# Altium schematic sheet MCU.SchDoc
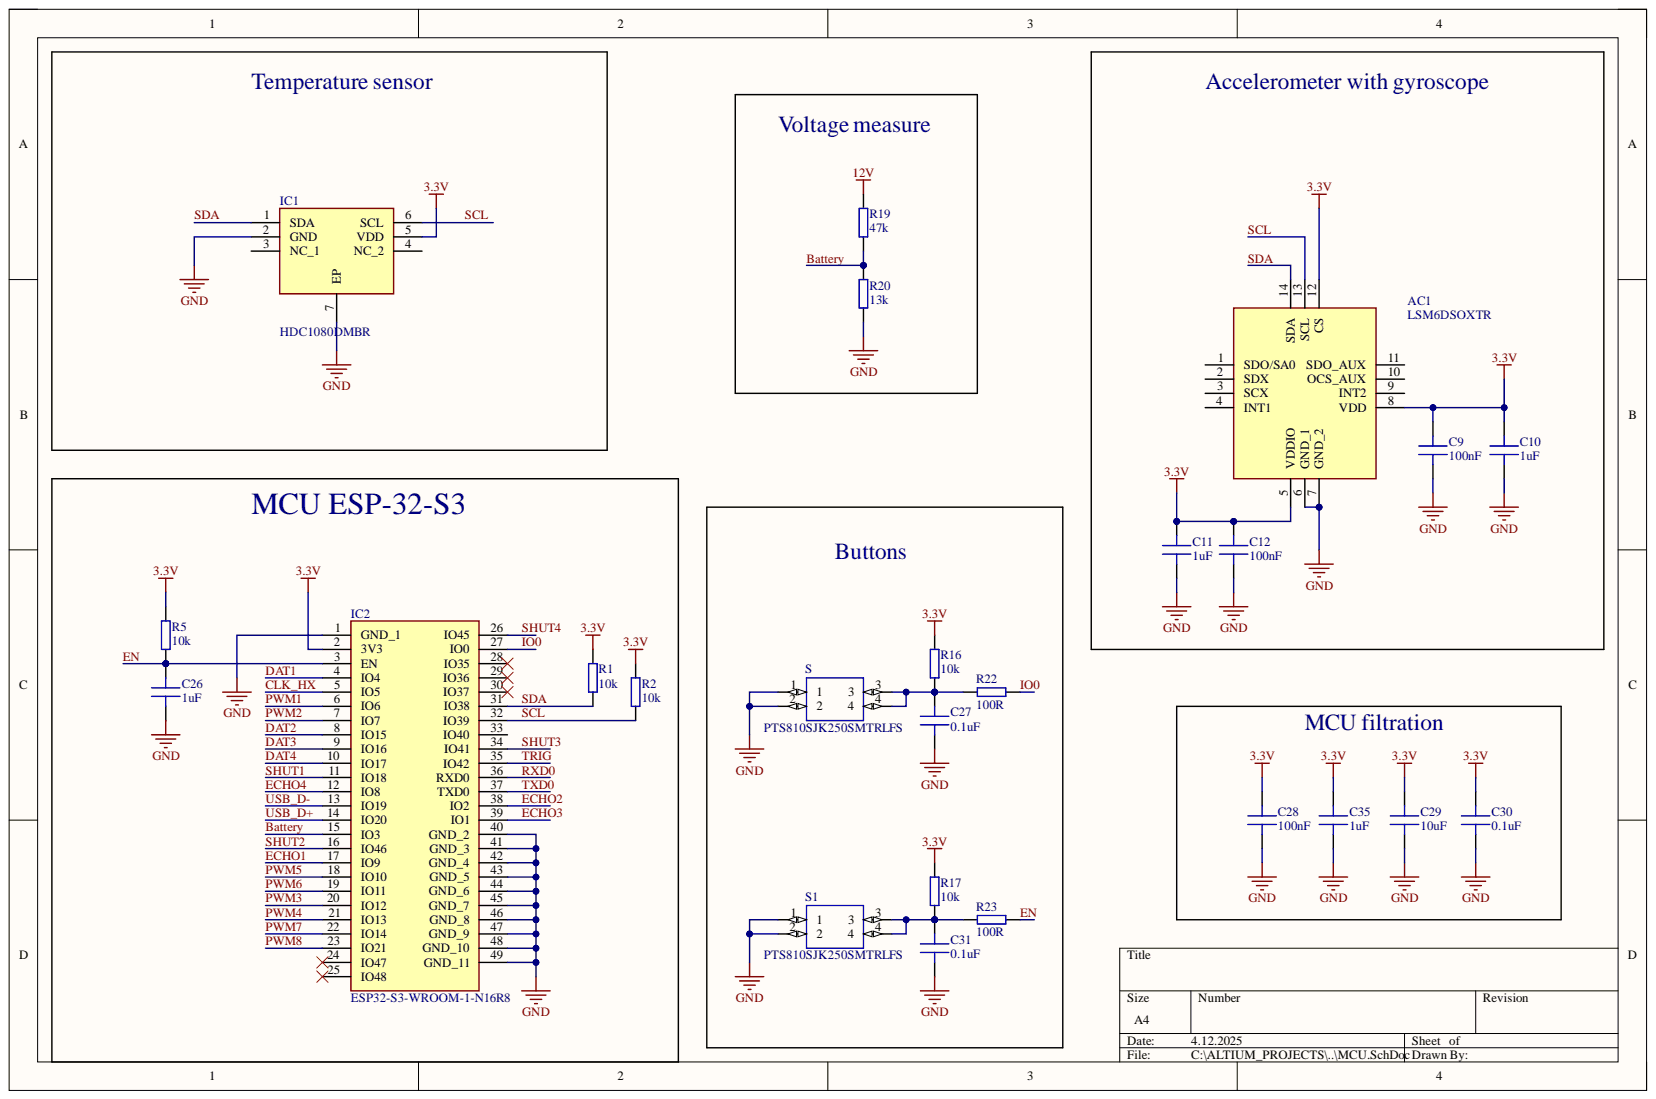
[diagram p0: MCU.SchDoc]

## Parts
AC1  LSM6DSOXTR  [LGA-14_1]
C9  100nF  [C 0402]
C10  1uF  [C 0402]
C11  1uF  [C 0402]
C12  100nF  [C 0402]
C26  1uF  [C 0402]
C27  0.1uF  [C 0402]
C28  100nF  [C 0402]
C29  10uF  [C 0402]
C30  0.1uF  [C 0402]
C31  0.1uF  [C 0402]
C35  1uF  [C 0402]
IC1  HDC1080DMBR  [SON100P300X300X80-7N-D]
IC2  ESP32-S3-WROOM-1-N16R8  [ESP32S3WROOM1N16R8]
R1  10k  [R 0402]
R2  10k  [R 0402]
R5  10k  [R 0402]
R16  10k  [R 0402]
R17  10k  [R 0402]
R19  47k  [R 0402]
R20  13k  [R 0402]
R22  100R  [R 0402]
R23  100R  [R 0402]
S  PTS810SJK250SMTRLFS  [PTS810SJG250SMTRLFS]
S1  PTS810SJK250SMTRLFS  [PTS810SJG250SMTRLFS]

## Nets
3.3V: AC1.5(VDDIO) AC1.8(VDD) AC1.12(CS) C9.1 C10.1 C11.1 C12.1 C28.1 C29.1 C30.1 C35.1 IC1.5(VDD) IC2.2(3V3) R1.2 R2.2 R5.2 R16.2 R17.2
12V: R19.2
GND: AC1.6(GND_1) AC1.7(GND_2) C9.2 C10.2 C11.2 C12.2 C26.2 C27.2 C28.2 C29.2 C30.2 C31.2 C35.2 IC1.2(GND) IC1.7(EP) IC2.1(GND_1) IC2.40(GND_2) IC2.41(GND_3) IC2.42(GND_4) IC2.43(GND_5) IC2.44(GND_6) IC2.45(GND_7) IC2.46(GND_8) IC2.47(GND_9) IC2.48(GND_10) IC2.49(GND_11) R20.1 S.1 S.2 S1.1 S1.2
Battery: IC2.15(IO3) R19.1 R20.2
CLK_HX: IC2.5(IO5)
DAT1: IC2.4(IO4)
DAT2: IC2.8(IO15)
DAT3: IC2.9(IO16)
DAT4: IC2.10(IO17)
ECHO1: IC2.17(IO9)
ECHO2: IC2.38(IO2)
ECHO3: IC2.39(IO1)
ECHO4: IC2.12(IO8)
EN: C26.1 IC2.3(EN) R5.1 R23.1
IO0: IC2.27(IO0) R22.1
PWM1: IC2.6(IO6)
PWM2: IC2.7(IO7)
PWM3: IC2.20(IO12)
PWM4: IC2.21(IO13)
PWM5: IC2.18(IO10)
PWM6: IC2.19(IO11)
PWM7: IC2.22(IO14)
PWM8: IC2.23(IO21)
RXD0: IC2.36(RXD0)
SCL: AC1.13(SCL) IC1.6(SCL) IC2.32(IO39) R2.1
SDA: AC1.14(SDA) IC1.1(SDA) IC2.31(IO38) R1.1
SHUT1: IC2.11(IO18)
SHUT2: IC2.16(IO46)
SHUT3: IC2.34(IO41)
SHUT4: IC2.26(IO45)
TRIG: IC2.35(IO42)
TXD0: IC2.37(TXD0)
USB_D+: IC2.14(IO20)
USB_D-: IC2.13(IO19)
NetC27_1: C27.1 R16.1 R22.2 S.3 S.4
NetC31_1: C31.1 R17.1 R23.2 S1.3 S1.4

Not connected: AC1 1(SDO/SA0) 2(SDX) 3(SCX) 4(INT1) 9(INT2) 10(OCS_AUX) 11(SDO_AUX); IC1 3(NC_1) 4(NC_2); IC2 24(IO47) 25(IO48) 28(IO35) 29(IO36) 30(IO37) 33(IO40)

## Library data of the parts
AC1 = LSM6DSOXTR: Accelerometer; Manufacturer_Name: STMicroelectronics; Arrow Part Number: LSM6DSOXTR; Arrow Price/Stock: https://www.arrow.com/en/products/lsm6dsoxtr/stmicroelectronics?region=europe; Component Kind: Standard; Datasheet Link: https://www.st.com/resource/en/datasheet/lsm6dsox.pdf; Manufacturer_Part_Number: LSM6DSOXTR; Mouser Part Number: 511-LSM6DSOXTR; Mouser Price/Stock: https://www.mouser.co.uk/ProductDetail/STMicroelectronics/LSM6DSOXTR?qs=l7cgNqFNU1i9dcjzItLpVQ%3D%3D; Library Name: caravan_sch_lib.SchLib
C9-C12 C26-C31 C35 = Capacitor: MLCC Capacitor; Component Kind: Standard; Library Name: Components.IntLib
IC1 = HDC1080DMBR: Integrated Circuit; Manufacturer_Name: Texas Instruments; Arrow Part Number: HDC1080DMBR; Arrow Price/Stock: https://www.arrow.com/en/products/hdc1080dmbr/texas-instruments?region=nac; Component Kind: Standard; Datasheet Link: http://www.ti.com/lit/gpn/HDC1080; Height: 0.8mm; Manufacturer_Part_Number: HDC1080DMBR; Mouser Part Number: 595-HDC1080DMBR; Mouser Price/Stock: https://www.mouser.co.uk/ProductDetail/Texas-Instruments/HDC1080DMBR?qs=8%2FmU9qzJpL9OuhPLRSO9Vg%3D%3D; Library Name: caravan_sch_lib.SchLib
IC2 = ESP32-S3-WROOM-1-N16R8: Integrated Circuit; Manufacturer_Name: Espressif Systems; Component Kind: Standard; Datasheet Link: https://www.espressif.com/sites/default/files/documentation/esp32-s3-wroom-1_wroom-1u_datasheet_en.pdf; Height: 3.25mm; Manufacturer_Part_Number: ESP32-S3-WROOM-1-N16R8; Mouser Part Number: 356-ESP32S3WRM1N16R8; Mouser Price/Stock: https://www.mouser.co.uk/ProductDetail/Espressif-Systems/ESP32-S3-WROOM-1-N16R8?qs=Li%252BoUPsLEnvQc9gW6AMhZg%3D%3D; Library Name: caravan_sch_lib.SchLib
R1 R2 R5 R16 R17 R19 R20 R22 R23 = Resistor: General pourpouse resistor; Component Kind: Standard; Library Name: Components.IntLib
S S1 = PTS810SJK250SMTRLFS: Tactile Switch SPST-NO Top Actuated Surface Mount; MF: C&K; Availability: Unavailable; Component Kind: Standard; MP: PTS810SJK250SMTRLFS; Package: None; Price: None; SnapEDA_Link: https://www.snapeda.com/parts/PTS810SJK250SMTRLFS/C%26K/view-part/274682/?ref=snap; Library Name: caravan_sch_lib.SchLib
